# Altium schematic sheet Power Selection - Power Supply.SchDoc
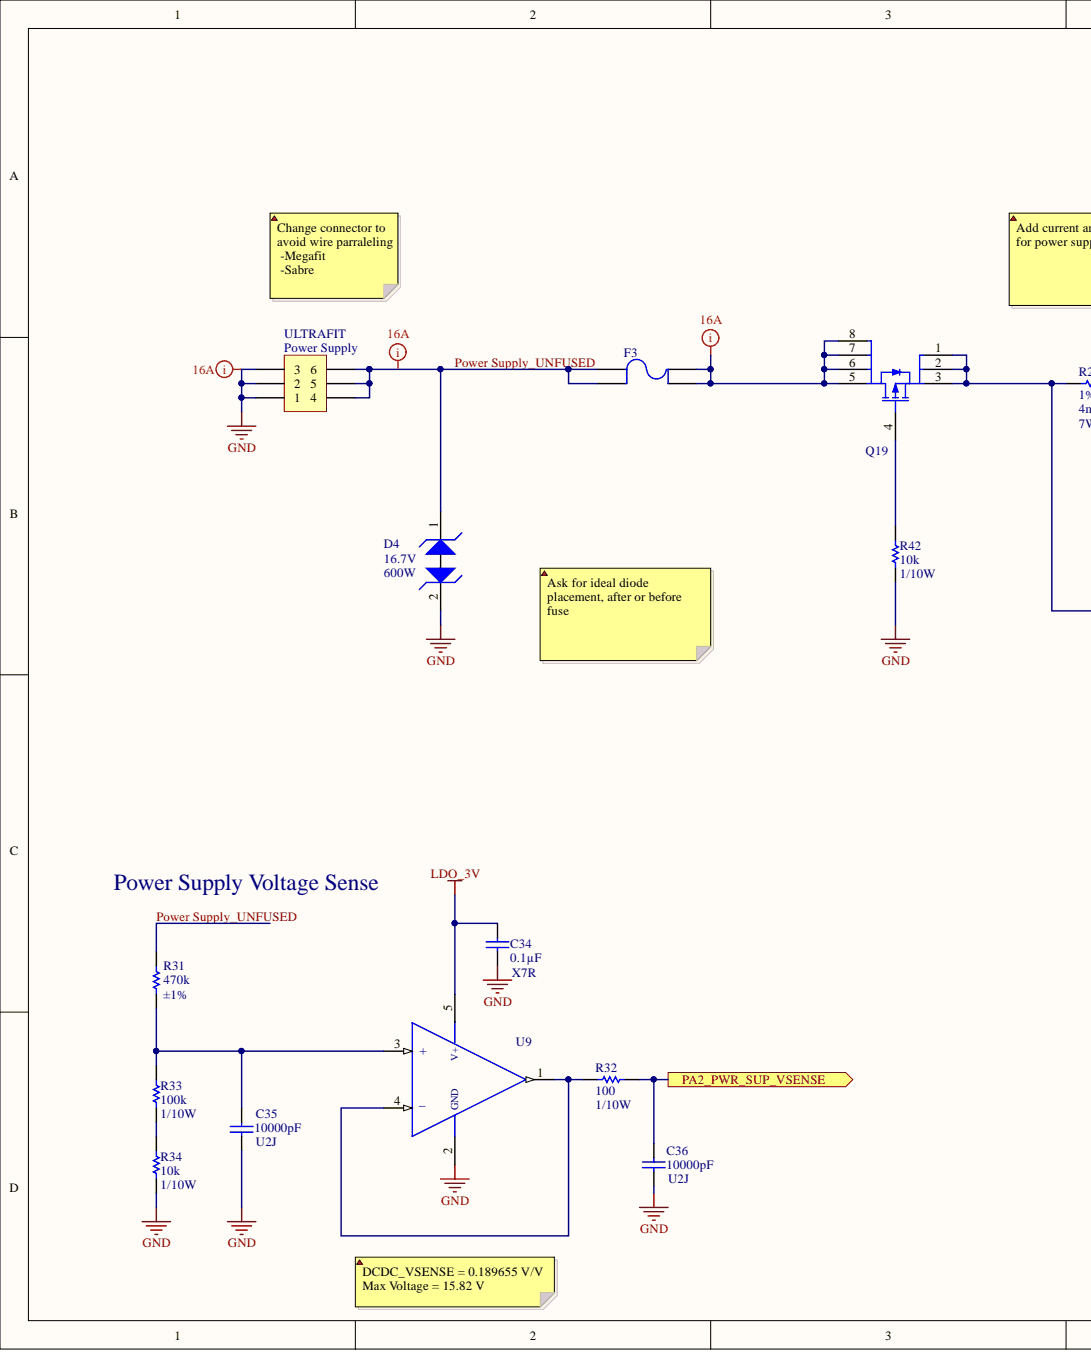
[diagram p0: Power Selection - Power Supply.SchDoc, left]
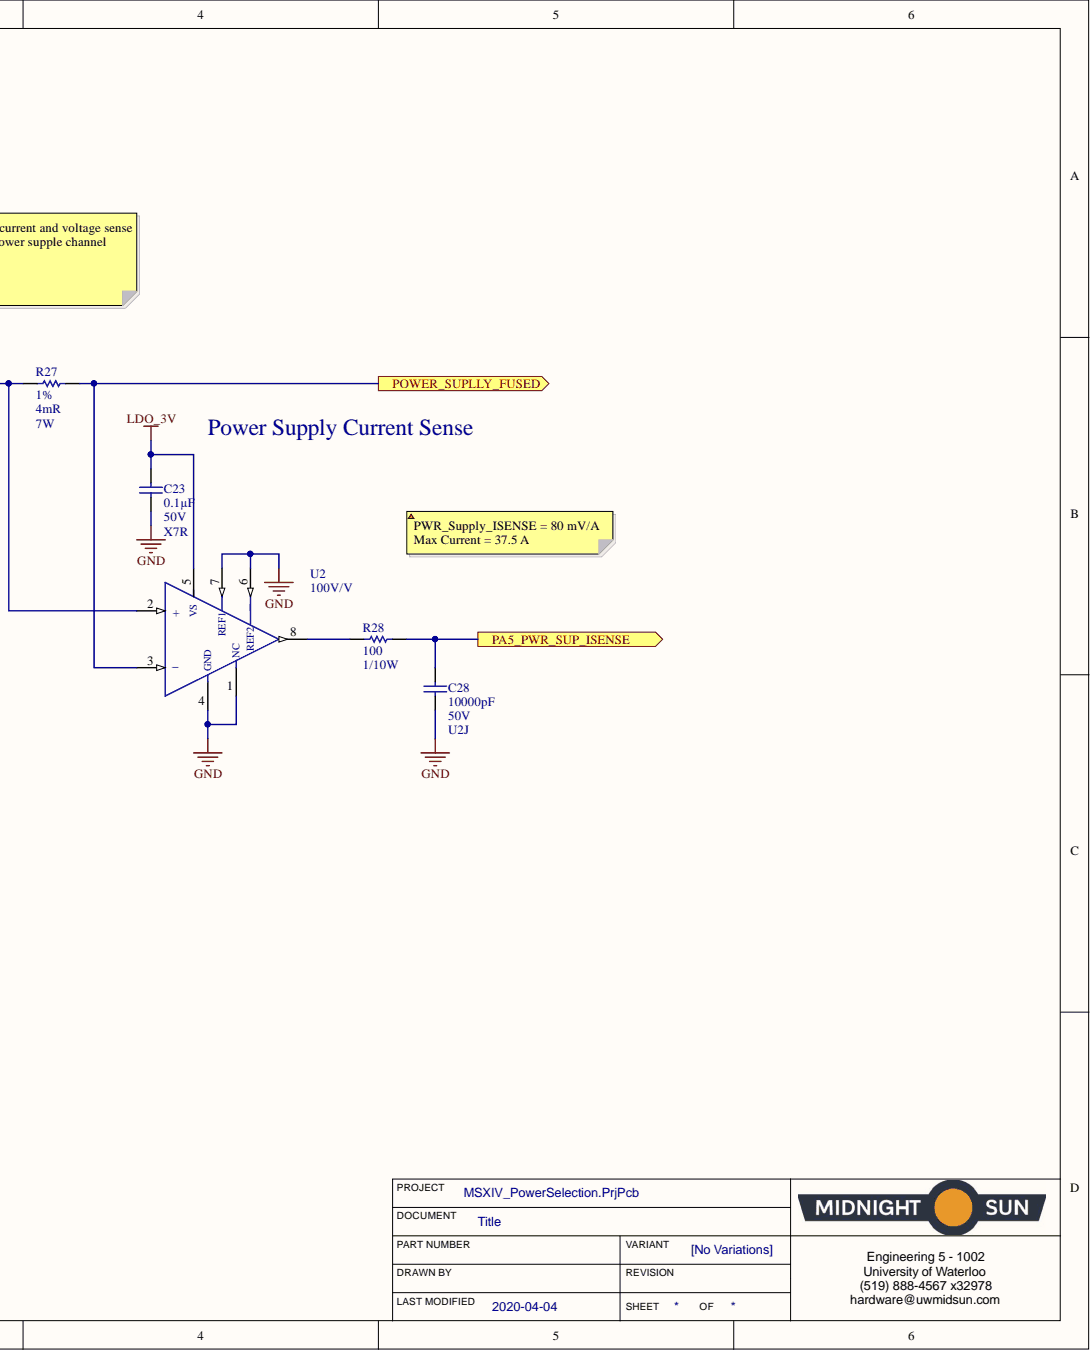
[diagram p1: Power Selection - Power Supply.SchDoc, right]

## Parts
C23  Capacitance: 0.1µF  Temperature Coefficient: X7R  Voltage: 50V  [CAP, 0603]  (hidden comment: CAP CER 0.1UF 50V 10% X7R 0603)
C28  Capacitance: 10000pF  Temperature Coefficient: U2J  Voltage: 50V  [CAP, 0603]  (hidden comment: CAP CER 10nF 50V 5% X7R 0603)
C34  Capacitance: 0.1µF  Temperature Coefficient: X7R  [CAP, 0603]  (hidden comment: CAP CER 0.1UF 50V 10% X7R 0603)
C35  Capacitance: 10000pF  Temperature Coefficient: U2J  [CAP, 0603]  (hidden comment: CAP CER 10nF 50V 5% X7R 0603)
C36  Capacitance: 10000pF  Temperature Coefficient: U2J  [CAP, 0603]  (hidden comment: CAP CER 10nF 50V 5% X7R 0603)
D4  Power - Peak Pulse: 600W  Voltage - Breakdown (Min): 16.7V  [DO-214AA Diode]  (hidden comment: DIODE TVS 15VWM 24.4VC DO-214AA (SMB))
F3  (no value)  [FUSE ATO/ATC FUSE HOLDER]
Power_Supply  Series: ULTRAFIT  [CONN, 6POS ULTRA-FIT]  (hidden comment: CONN 6POS ULTRA-FIT 0.138")
Q19  MOSFET P-CH PWR56 40V 4.9 MOHM  [PMOS PWR56 40V 4.9 MOHM]  (value not drawn)
R27  Max Power Dissipation: 7W  Resistance: 4mR  Tolerance: 1%  [RES 5931]  (hidden comment: RES 0.004 OHM 1% 7W 5931)
R28  Power: 1/10W  [RES, 0603]  (hidden comment: RES 100 OHM 1% 1/10W 0603)
R31  Resistance: 470k  Tolerance: ±1%  [RES, 0603]  (hidden comment: RES 470K OHM 1% 1/4W 0603)
R32  Power: 1/10W  [RES, 0603]  (hidden comment: RES 100 OHM 1% 1/10W 0603)
R33  Power: 1/10W  Resistance: 100k  [RES, 0603]  (hidden comment: RES 100K OHM 5% 1/8W 0603)
R34  Power: 1/10W  Resistance: 10k  [RES, 0603]  (hidden comment: RES 10K OHM 1% 1/10W 0603)
R42  Power: 1/10W  Resistance: 10k  [RES, 0603]  (hidden comment: RES 10K OHM 1% 1/10W 0603)
U2  100V/V  [IC CURRENT AMPLIFIER INA240 TSSOP-8]
U9  IC OP AMP GEN PURPOSE RR 10MHZ SOT-23-5  [IC OP AMP GP RR 10MHZ SOT-23-5]  (value not drawn)

## Ports
PA2_PWR_SUP_VSENSE, PA5_PWR_SUP_ISENSE, POWER_SUPLLY_FUSED

## Nets
GND: C23.2 C28.2 C34.2 C35.2 C36.2 D4.2 Power_Supply.1 Power_Supply.2 Power_Supply.3 R34.2 R42.2 U2.1(NC) U2.4(GND) U2.6 U2.7(REF1) U9.2
LDO_3V: C23.1 C34.1 U2.5(VS) U9.5
PA2_PWR_SUP_VSENSE: C36.1 R32.2 ; port PA2_PWR_SUP_VSENSE
PA5_PWR_SUP_ISENSE: C28.1 R28.2 ; port PA5_PWR_SUP_ISENSE
Power Supply_UNFUSED: D4.1 F3.1 F3.3 Power_Supply.4 Power_Supply.5 Power_Supply.6 R31.1
POWER_SUPLLY_FUSED: R27.2 U2.3(_) ; port POWER_SUPLLY_FUSED
NetC35_1: C35.1 R31.2 R33.1 U9.3(+)
NetF3_2: F3.2 F3.4 Q19.5 Q19.6 Q19.7 Q19.8
NetQ19_1: Q19.1 Q19.2 Q19.3 R27.1 U2.2(+)
NetQ19_4: Q19.4 R42.1
NetR28_1: R28.1 U2.8
NetR32_1: R32.1 U9.1 U9.4
NetR33_2: R33.2 R34.1

## Library data of the parts
C23 C34 = CAP CER 0.1UF 50V 10% X7R 0603: 0.10µF ±10% 50V Ceramic Capacitor X7R 0603 (1608 Metric); Manufacturer 1: AVX Corporation; Manufacturer Part Number 1: 06035C104KAT2A; Component Kind: Standard; ComponentLink1Description: http://datasheets.avx.com/X7RDielectric.pdf; ComponentLink1URL: http://datasheets.avx.com/X7RDielectric.pdf; Height - Seated (Max): -; Lead Spacing: -; Lead Style: -; Mounting Type: Surface Mount, MLCC; Operating Temperature: -55°C ~ 125°C; Package / Case: 0603 (1608 Metric); Packaging: Cut Tape (CT); Part Status: Active; Ratings: -; Size / Dimension: 0.063" L x 0.032" W (1.60mm x 0.81mm); Supplier 1: Digi-Key; Supplier Part Number 1: 478-5052-1-ND; Thickness (Max): 0.035" (0.90mm); Tolerance: ±10%; Library Name: Schematic Diagrams.SchLib
  C34: Voltage: 50V
C28 C35 C36 = CAP CER 10nF 50V 5% X7R 0603: 10000pF ±5% 50V Ceramic Capacitor U2J 0603 (1608 Metric); Manufacturer 1: KEMET; Manufacturer Part Number 1: C0603C103J5JAC7867; Component Kind: Standard; ComponentLink1Description: http://www.kemet.com/docfinder?Partnumber=C0603C103J5JAC7867; ComponentLink1URL: http://www.kemet.com/docfinder?Partnumber=C0603C103J5JAC7867; Failure Rate: -; Height - Seated (Max): -; Lead Spacing: -; Lead Style: -; Mounting Type: Surface Mount, MLCC; Operating Temperature: -55°C ~ 125°C; Package / Case: 0603 (1608 Metric); Packaging: Cut Tape (CT); Part Status: Active; Ratings: -; Size / Dimension: 0.063" L x 0.032" W (1.60mm x 0.80mm); Supplier 1: Digi-Key; Supplier Part Number 1: 399-13384-1-ND; Thickness (Max): 0.034" (0.87mm); Tolerance: ±5%; Library Name: Schematic Diagrams.SchLib
  C35 C36: Voltage: 50V
D4 = DIODE TVS 15VWM 24.4VC DO-214AA (SMB): TVS DIODE 15VWM 24.4VC SMB; Manufacturer 1: Fairchild/ON Semiconductor; Manufacturer Part Number 1: SMBJ15CA; Current - Peak Pulse (10/1000µs): 24.6A; Power Line Protection: No; Type: Zener; Voltage - Clamping (Max) @ Ipp: 24.4V; Voltage - Reverse Standoff (Typ): 15V; Applications: General Purpose; Capacitance @ Frequency: -; Category: Circuit Protection; Component Kind: Standard; ComponentLink1Description: https://www.fairchildsemi.com/datasheets/SM/SMBJ10CA.pdf; ComponentLink1URL: https://www.fairchildsemi.com/datasheets/SM/SMBJ10CA.pdf; Description 1: TVS DIODE 15VWM 24.4VC SMB; Mounting Type: Surface Mount; Operating Temperature: -55°C ~ 150°C (TJ); Package / Case: DO-214AA, SMB; Packaging: Cut Tape (CT); Part Status: Active; Pricing 1: 1=0.54, 10=0.444, 100=0.3027, 500=0.22704, 1000=0.17028 (CAD); RoHS: RoHS Compliant; Stock 1: 24965; Supplier 1: Digi-Key; Supplier Device Package: DO-214AA (SMB); Supplier Part Number 1: SMBJ15CAFSCT-ND; Library Name: Schematic Diagrams.SchLib
F3 = FUSE ATO FUSE HOLDER: Fuse Block 30A 500V 1 Circuit Blade PCB; Manufacturer 1: Keystone Electronics; Manufacturer Part Number 1: 3557-2; Description 1: FUSE BLOCK BLADE 500V 30A PCB; For Use With/Related Products: ATC, ATO 257, MINI 897; Fuse Size: 0.43" L x 0.15" W x 0.64" H (10.92mm x 3.81mm x 16.25mm); Number of Circuits: 1; Category: Circuit Protection; Component Kind: Standard; ComponentLink1Description: http://www.keyelco.com/product-pdf.cfm?p=296; ComponentLink1URL: http://www.keyelco.com/product-pdf.cfm?p=296; Contact Finish: Tin Nickel; Contact Material: Brass; Current Rating: 30A; Fuseholder Type: Block; Fuse Type: Blade; Mounting Type: PCB; Orientation: Vertical; Part Status: Active; Pricing 1: 1=1.66, 10=1.442, 50=1.3008, 100=1.2441, 250=1.13096, 500=1.01784, 1000=1.0126, 2500=0.84861, 5000=0.81519 (CAD); RoHS: RoHS Compliant; Stock 1: 48838; Supplier 1: Digi-Key; Supplier Part Number 1: 36-3557-2-ND; Termination Style: PC Pin; Voltage: 500V; Library Name: Schematic Diagrams.SchLib
Power_Supply = CONN 6POS ULTRA-FIT 0.138": 6 Positions Header Connector 0.138" (3.50mm) Through Hole Tin; Manufacturer 1: Molex, LLC; Manufacturer Part Number 1: 1722991106; Contact Length - Post: 0.126" (3.20mm); Mated Stacking Heights: 26.99mm; Material Flammability Rating: UL94 V-0; Number of Positions: 6; Number of Positions Loaded: All; Row Spacing - Mating: 0.181" (4.60mm); Shrouding: Shrouded - 4 Wall; Style: Board to Cable/Wire; Voltage: 400V; Component Kind: Standard; ComponentLink1Description: http://www.molex.com/pdm_docs/sd/1722991106_sd.pdf; ComponentLink1URL: http://www.molex.com/pdm_docs/sd/1722991106_sd.pdf; Connector Type: Header; Contact Finish - Mating: Tin; Contact Finish - Post: Tin; Contact Finish Thickness - Mating: 60µin (1.52µm); Contact Finish Thickness - Post: 60µin (1.52µm); Contact Length - Mating: -; Contact Material: Copper Alloy; Contact Shape: Square; Contact Type: Male Pin; Current Rating: -; Fastening Type: Latch Holder; Ingress Protection: -; Insulation Color: Black; Insulation Height: 0.465" (11.80mm); Insulation Material: Liquid Crystal Polymer (LCP); Mounting Type: Through Hole; Number of Rows: 2; Operating Temperature: -40°C ~ 105°C; Overall Contact Length: -; Packaging: Tray; Part Status: Active; Pitch - Mating: 0.138" (3.50mm); Supplier 1: Digi-Key; Supplier Part Number 1: WM11778-ND; Termination: Solder; Library Name: Schematic Diagrams.SchLib
Q19 = MOSFET P-CH PWR56 40V 4.9 MOHM: P-Channel 16V 2.5A (Ta) 650mW (Ta) Surface Mount X2-DFN2015-3; Current - Continuous Drain (Id) @ 25°C: 80A (Tc); Drive Voltage (Max Rds On,  Min Rds On): 4.5V, 10V; Gate Charge (Qg) (Max) @ Vgs: 107nC @ 10V; Input Capacitance (Ciss) (Max) @ Vds: 4840pF @ 20V; Power Dissipation (Max): 214W (Tj); Rds On (Max) @ Id, Vgs: 4.9mOhm @ 80A, 10V; Vgs(th) (Max) @ Id: 3V @ 250µA; Component Kind: Standard; ComponentLink1Description: https://www.onsemi.com/pub/Collateral/FDWS9508L-F085-D.PDF; ComponentLink1URL: https://www.onsemi.com/pub/Collateral/FDWS9508L-F085-D.PDF; Drain to Source Voltage (Vdss): 40V; FET Feature: -; FET Type: P-Channel; Mounting Type: Surface Mount; Operating Temperature: -55°C ~ 175°C (TJ); Package / Case: 8-PowerTDFN; Packaging: Cut Tape (CT); Part Status: Active; Supplier 1: Digi-Key; Supplier Device Package: FDWS9508L-F085OSCT-ND; Supplier Part Number 1: FDWS9508L-F085OSCT-ND; Technology: MOSFET (Metal Oxide); Vgs (Max): ±16V; Library Name: Schematic Diagrams.SchLib
R27 = RES 0.004 OHM 1% 7W 5931: 4 mOhms ±1% 7W Chip Resistor Nonstandard Automotive AEC-Q200, Current Sense, Moisture Resistant Metal Element; Manufacturer 1: Yageo; Manufacturer Part Number 1: PU5931FKMP70R004L; Description 1: RES SMD 0.004 OHM 1% 7W 5931; Temperature Coefficient: 75ppm/°C; Case/Package: 5931; Component Kind: Standard; ComponentLink1Description: http://www.yageo.com/documents/recent/PYu-PU_51_RoHS_L_3.pdf; ComponentLink1URL: http://www.yageo.com/documents/recent/PYu-PU_51_RoHS_L_3.pdf; Composition: Metal Element; Height: 0.015inch; Length: 0.591inch; Max Operating Temperature: 170°C; Min Operating Temperature: -65°C; Number of Terminations: 2; Packaging: Cut Tape; Pricing 1: 1=2.06, 10=1.823, 25=1.6904, 50=1.5912, 100=1.3922, 250=1.19336, 500=0.99446 (USD); Stock 1: 1097; Supplier 1: Digi-Key; Supplier Part Number 1: YAG4096CT-ND; Width: 0.305inch; Library Name: Schematic Diagrams.SchLib
R28 R32 = RES 100 OHM 1% 1/10W 0603: 100 Ohm ±1% 0.1W, 1/10W Chip Resistor 0603 (1608 Metric) Moisture Resistant Thick Film; Manufacturer 1: Yageo; Manufacturer Part Number 1: RC0603FR-07100RL; Resistance: 100; Temperature Coefficient: ±100ppm/°C; Component Kind: Standard; ComponentLink1Description: http://www.yageo.com/documents/recent/PYu-RC_Group_51_RoHS_L_7.pdf; ComponentLink1URL: http://www.yageo.com/documents/recent/PYu-RC_Group_51_RoHS_L_7.pdf; Composition: Thick Film; Failure Rate: -; Height - Seated (Max): 0.022" (0.55mm); Operating Temperature: -55°C ~ 155°C; Package / Case: 0603 (1608 Metric); Packaging: Cut Tape (CT); Part Status: Active; Size / Dimension: 0.063" L x 0.031" W (1.60mm x 0.80mm); Supplier 1: Digi-Key; Supplier Device Package: 0603; Supplier Part Number 1: 311-100HRCT-ND; Tolerance: ±1%; Library Name: Schematic Diagrams.SchLib
R31 = RES 470K OHM 1% 1/4W 0603: RES SMD 470K OHM 1% 1/4W 0603; Manufacturer 1: Panasonic Electronic Components; Manufacturer Part Number 1: ERJ-PA3F4703V; Temperature Coefficient: ±100ppm/°C; Component Kind: Standard; ComponentLink1Description: https://industrial.panasonic.com/cdbs/www-data/pdf/RDO0000/AOA0000C291.pdf; ComponentLink1URL: https://industrial.panasonic.com/cdbs/www-data/pdf/RDO0000/AOA0000C291.pdf; Composition: Thick Film; Failure Rate: -; Height - Seated (Max): 0.022" (0.55mm); Operating Temperature: -55°C ~ 155°C; Package / Case: 0603 (1608 Metric); Packaging: Cut Tape (CT); Part Status: Active; Size / Dimension: 0.063" L x 0.031" W (1.60mm x 0.80mm); Supplier 1: Digi-Key; Supplier Device Package: 0603; Supplier Part Number 1: P470KBYCT-ND; Library Name: Schematic Diagrams.SchLib
R33 = RES 100K OHM 5% 1/8W 0603: RES SMD 100K OHM 5% 1/10W 0603; Manufacturer 1: Yageo; Manufacturer Part Number 1: RC0603JR-07100KL; Temperature Coefficient: ±100ppm/°C; Component Kind: Standard; ComponentLink1Description: http://www.yageo.com/documents/recent/PYu-RC_Group_51_RoHS_L_7.pdf; ComponentLink1URL: http://www.yageo.com/documents/recent/PYu-RC_Group_51_RoHS_L_7.pdf; Composition: Thick Film; Failure Rate: -; Height - Seated (Max): 0.022" (0.55mm); Operating Temperature: -55°C ~ 155°C; Package / Case: 0603 (1608 Metric); Packaging: Cut Tape (CT); Part Status: Active; Size / Dimension: 0.063" L x 0.031" W (1.60mm x 0.80mm); Supplier 1: Digi-Key; Supplier Device Package: 0603; Supplier Part Number 1: 311-100KGRCT-ND; Tolerance: ±5%; Library Name: Schematic Diagrams.SchLib
R34 R42 = RES 10K OHM 1% 1/10W 0603: RES SMD 10K OHM 1% 1/10W 0603; Manufacturer 1: Yageo; Manufacturer Part Number 1: RC0603FR-0710KL; Temperature Coefficient: ±100ppm/°C; Component Kind: Standard; ComponentLink1Description: http://www.yageo.com/documents/recent/PYu-RC_Group_51_RoHS_L_7.pdf; ComponentLink1URL: http://www.yageo.com/documents/recent/PYu-RC_Group_51_RoHS_L_7.pdf; Composition: Thick Film; Failure Rate: -; Height - Seated (Max): 0.022" (0.55mm); Operating Temperature: -55°C ~ 155°C; Package / Case: 0603 (1608 Metric); Packaging: Cut Tape (CT); Part Status: Active; Size / Dimension: 0.063" L x 0.031" W (1.60mm x 0.80mm); Supplier 1: Digi-Key; Supplier Device Package: 0603; Supplier Part Number 1: 311-10.0KHRCT-ND; Tolerance: ±1%; Library Name: Schematic Diagrams.SchLib
U2 = IC CURRENT AMPLIFIER INA240 8-TSSOP: Current Sense Amplifier 1 Circuit 8-TSSOP; Manufacturer 1: Texas Instruments; Manufacturer Part Number 1: INA240A3PWR; Current - Supply: 1.8mA; Description 1: IC AMP CURRENT SENSE 8-TSSOP; Slew Rate: 2 V/µs; Voltage - Supply, Single/Dual (±): 2.7 V ~ 5.5 V; Amplifier Type: Current Sense; Category: Integrated Circuits (ICs); Component Kind: Standard; ComponentLink1Description: http://www.ti.com/lit/ds/symlink/ina240.pdf; ComponentLink1URL: http://www.ti.com/lit/ds/symlink/ina240.pdf; Mounting Type: Surface Mount; Operating Temperature: -40°C ~ 125°C; Output Type: -; Package / Case: 8-TSSOP (0.173", 4.40mm Width); Packaging: Cut Tape (CT); Part Status: Active; Pricing 1: 1=3.79, 10=3.397, 100=2.7311, 500=2.24388, 1000=1.85921 (CAD); RoHS: RoHS Compliant; Stock 1: 1286; Supplier 1: Digi-Key; Supplier Device Package: 8-TSSOP; Supplier Part Number 1: 296-45090-1-ND; Library Name: Schematic Diagrams.SchLib
U9 = IC OP AMP GEN PURPOSE RR 10MHZ SOT-23-5: General Purpose Amplifier 1 Circuit Rail-to-Rail SOT-23-5; Manufacturer 1: Texas Instruments; Manufacturer Part Number 1: TLV316QDBVRQ1; Current - Output / Channel: 50mA; Current - Supply: 400µA; Description 1: IC OP AMP GP RR 10MHZ 5-SOT-23; Number of Circuits: 1; Slew Rate: 6 V/µs; Voltage - Supply, Single/Dual (±): 1.8 V ~ 5.5 V, ±0.9 V ~ 2.75 V; -3db Bandwidth: -; Amplifier Type: General Purpose; Category: Integrated Circuits (ICs); Component Kind: Standard; ComponentLink1Description: http://www.ti.com/lit/ds/symlink/tlv316-q1.pdf; ComponentLink1URL: http://www.ti.com/lit/ds/symlink/tlv316-q1.pdf; Mounting Type: Surface Mount; Operating Temperature: -40°C ~ 125°C; Output Type: Rail-to-Rail; Package / Case: SC-74A, SOT-753; Packaging: Cut Tape (CT); Part Status: Active; Pricing 1: 1=1.33, 10=1.185, 100=0.9234, 500=0.76276, 1000=0.60218 (CAD); RoHS: RoHS Compliant; Stock 1: 1747; Supplier 1: Digi-Key; Supplier Device Package: SOT-23-5; Supplier Part Number 1: 296-45323-1-ND; Library Name: Schematic Diagrams.SchLib
